# Altium schematic sheet SIM808+RF.SchDoc
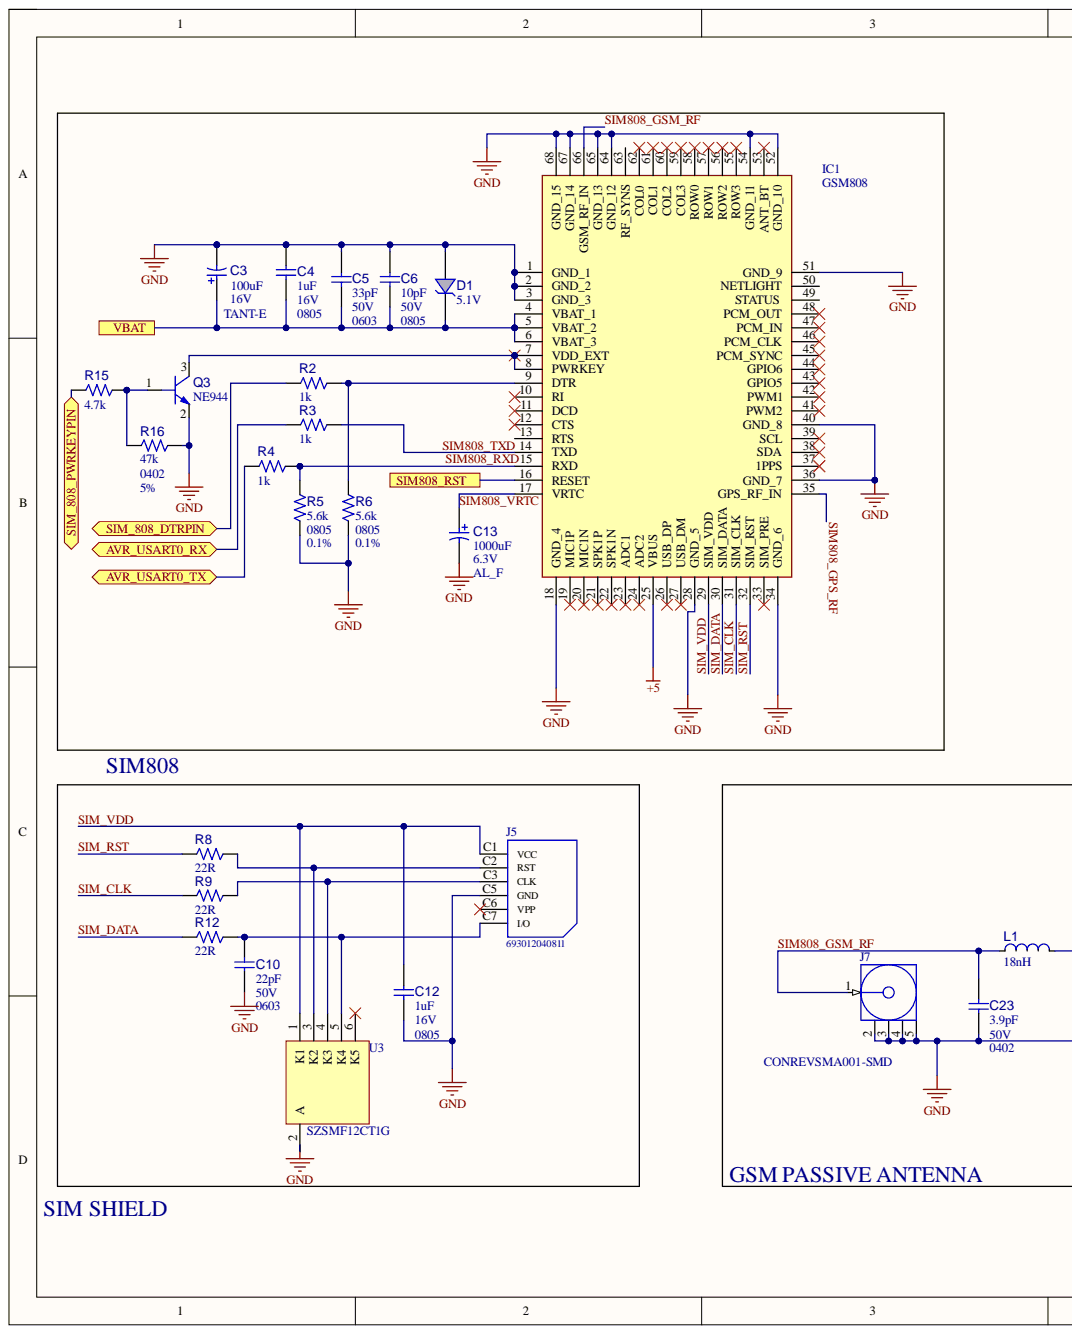
[diagram p0: SIM808+RF.SchDoc, left]
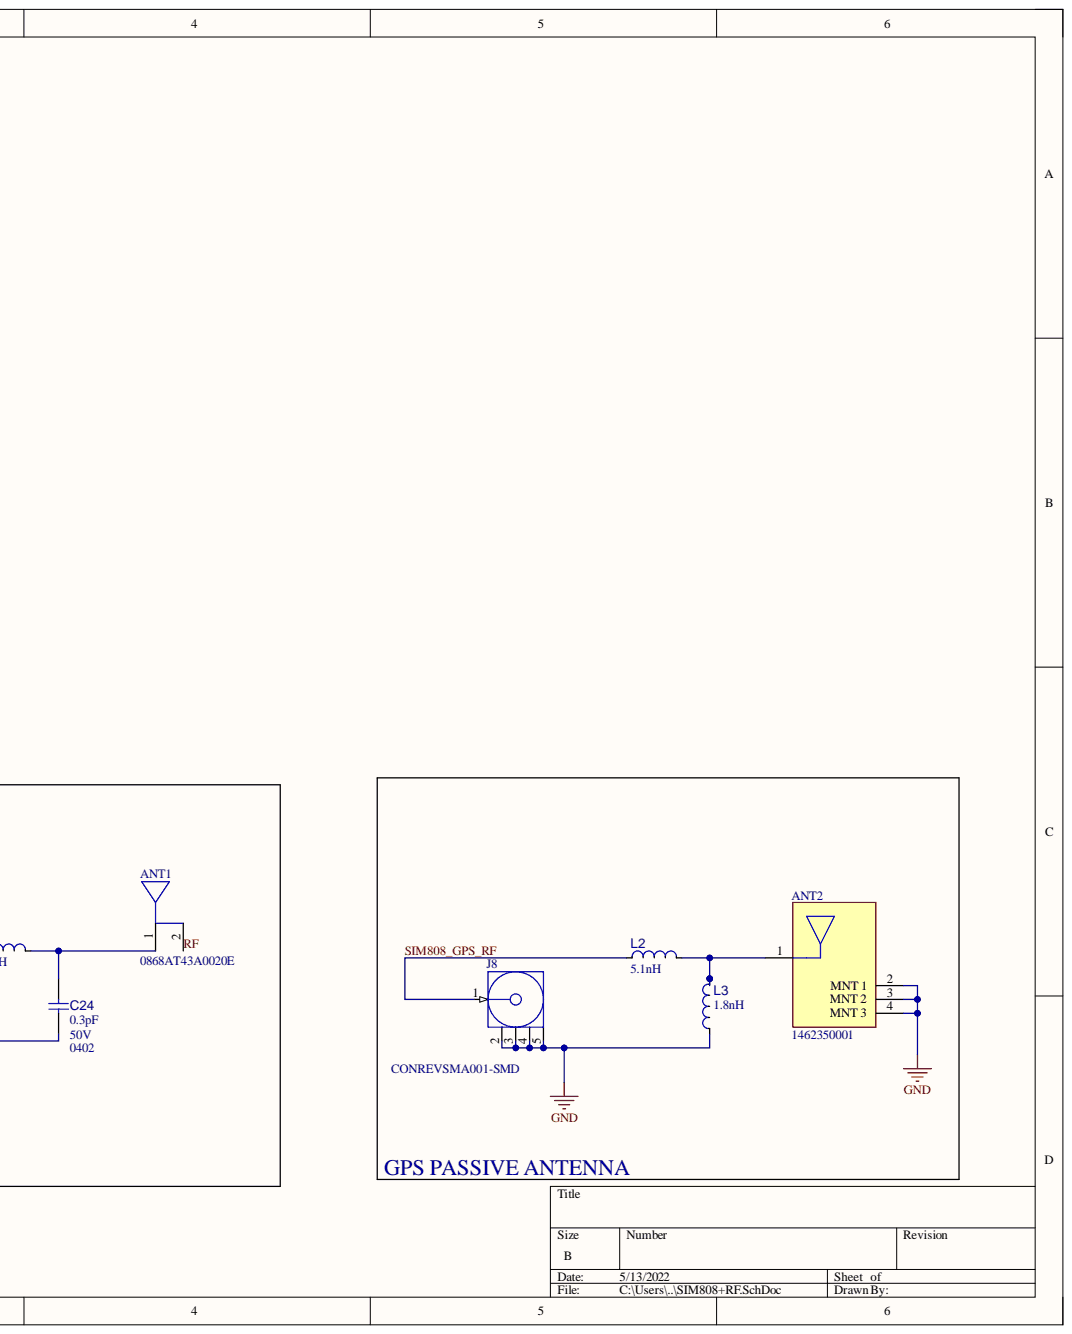
[diagram p1: SIM808+RF.SchDoc, right]

## Parts
ANT1  0868AT43A0020E  [FP-0900AT43A0070E-MFG]
ANT2  1462350001  [FP-1462350001-MFG]
C3  value 100uF  Package: TANT-E  Voltage: 16V  [CAP_TANT_E]  (hidden comment: Capacitor POL)
C4  value 1uF  Package: 0805  Voltage: 16V  [CAP_C_0805]  (hidden comment: Capacitor NP)
C5  value 33pF  Package: 0603  Voltage: 50V  [CAP_C_0603]  (hidden comment: Capacitor NP)
C6  value 10pF  Package: 0805  Voltage: 50V  [CAP_C_0805]  (hidden comment: Capacitor NP)
C10  value 22pF  Package: 0603  Voltage: 50V  [CAP_C_0603]  (hidden comment: Capacitor NP)
C12  value 1uF  Package: 0805  Voltage: 16V  [CAP_C_0805]  (hidden comment: Capacitor NP)
C13  value 1000uF  Package: AL_F  Voltage: 6.3V  [CAP_AL_F]  (hidden comment: Capacitor POL)
C23  value 3.9pF  Package: 0402  Voltage: 50V  [CAP_C_0402]  (hidden comment: Capacitor NP)
C24  value 0.3pF  Package: 0402  Voltage: 50V  [CAP_C_0402]  (hidden comment: Capacitor NP)
D1  value 5.1V  [SOD-123]  (hidden comment: Diode Zener)
IC1  GSM808  [GSM808]
J5  693012040811  [693012040811]
J7  CONREVSMA001-SMD  [CON-REVSMA-SMD]  (value not drawn)
J8  CONREVSMA001-SMD  [CON-REVSMA-SMD]  (value not drawn)
L1  value 18nH  [IND_C_0402]  (hidden comment: Inductor)
L2  value 5.1nH  [IND_C_0402]  (hidden comment: Inductor)
L3  value 1.8nH  [IND_C_0402]  (hidden comment: Inductor)
Q3  value NE944  [SOT-23-3]  (hidden comment: Transistor NPN BEC)
R2  value 1k  [RES_C_0603]  (hidden comment: Resistor)
R3  value 1k  [RES_C_0603]  (hidden comment: Resistor)
R4  value 1k  [RES_C_0603]  (hidden comment: Resistor)
R5  value 5.6k  Package: 0805  Tolerance: 0.1%  [RES_C_0805]  (hidden comment: Resistor)
R6  value 5.6k  Package: 0805  Tolerance: 0.1%  [RES_C_0805]  (hidden comment: Resistor)
R8  value 22R  [RES_C_8230]  (hidden comment: Resistor)
R9  value 22R  [RES_C_8230]  (hidden comment: Resistor)
R12  value 22R  [RES_C_8230]  (hidden comment: Resistor)
R15  value 4.7k  [RES_C_0603]  (hidden comment: Resistor)
R16  value 47k  Package: 0402  Tolerance: 5%  [RES_C_0402]  (hidden comment: Resistor)
U3  SZSMF12CT1G  [ONSC-SC70-6-419B-02_W_V]

## Ports
AVR_USART0_RX, AVR_USART0_TX, SIM808_RST, SIM_808_DTRPIN, SIM_808_PWRKEYPIN, VBAT

## Nets
+5: IC1.25(VBUS)
GND: ANT2.2(MNT_1) ANT2.3(MNT_2) ANT2.4(MNT_3) C3.2 C4.1 C5.1 C6.1 C10.1 C12.2 C13.2 C23.1 C24.1 D1.1 IC1.1(GND_1) IC1.2(GND_2) IC1.3(GND_3) IC1.18(GND_4) IC1.28(GND_5) IC1.34(GND_6) IC1.36(GND_7) IC1.40(GND_8) IC1.51(GND_9) IC1.52(GND_10) IC1.54(GND_11) IC1.64(GND_12) IC1.65(GND_13) IC1.67(GND_14) IC1.68(GND_15) J5.C5(GND) J7.2 J7.3 J7.4 J7.5 J8.2 J8.3 J8.4 J8.5 L3.1 Q3.2 R5.2 R6.2 R16.1 U3.2(A)
AVR_USART0_RX: R3.1 ; port AVR_USART0_RX
AVR_USART0_TX: R4.1 ; port AVR_USART0_TX
RF: ANT1.2
SIM808_GPS_RF: IC1.35(GPS_RF_IN) J8.1 L2.1
SIM808_GSM_RF: C23.2 IC1.66(GSM_RF_IN) J7.1 L1.1
SIM808_RST: IC1.16(RESET) ; port SIM808_RST
SIM808_RXD: IC1.15(RXD) R4.2 R5.1
SIM808_TXD: IC1.14(TXD) R3.2
SIM808_VRTC: C13.1 IC1.17(VRTC)
SIM_808_DTRPIN: R2.1 ; port SIM_808_DTRPIN
SIM_808_PWRKEYPIN: R15.1 ; port SIM_808_PWRKEYPIN
SIM_CLK: IC1.31(SIM_CLK) R9.1
SIM_DATA: IC1.30(SIM_DATA) R12.1
SIM_RST: IC1.32(SIM_RST) R8.1
SIM_VDD: C12.1 IC1.29(SIM_VDD) J5.C1(VCC) U3.1(K1)
VBAT: C3.1 C4.2 C5.2 C6.2 D1.2 IC1.4(VBAT_1) IC1.5(VBAT_2) IC1.6(VBAT_3) ; port VBAT
NetANT1_1: ANT1.1 C24.2 L1.2
NetANT2_1: ANT2.1 L2.2 L3.2
NetC10_2: C10.2 J5.C7(I/O) R12.2 U3.5(K4)
NetIC1_7: IC1.7(VDD_EXT) IC1.8(PWRKEY) Q3.3
NetIC1_9: IC1.9(DTR) R2.2 R6.1
NetJ5_C2: J5.C2(RST) R8.2 U3.3(K2)
NetJ5_C3: J5.C3(CLK) R9.2 U3.4(K3)
NetQ3_1: Q3.1 R15.2 R16.2

Not connected: IC1 10(RI) 11(DCD) 12(CTS) 13(RTS) 19(MIC1P) 20(MIC1N) 21(SPK1P) 22(SPK1N) 23(ADC1) 24(ADC2) 26(USB_DP) 27(USB_DM) 33(SIM_PRE) 37(1PPS) 38(SDA) 39(SCL) 41(PWM2) 42(PWM1) 43(GPIO5) 44(GPIO6) 45(PCM_SYNC) 46(PCM_CLK) 47(PCM_IN) 48(PCM_OUT) 49(STATUS) 50(NETLIGHT) 53(ANT_BT) 55(ROW3) 56(ROW2) 57(ROW1) 58(ROW0) 59 60 61 62(COL0) 63(RF_SYNS); J5 C6(VPP); U3 6(K5)

## Library data of the parts
ANT1 = CMP-56579-000002-1: RF ANT CHIP SOLDER SMD; Impedance: 50R; Max Frequency: 928MHz; Component Kind: Standard; Contact Plating: Tin; Library Name: Altium Content Vault; Mount: Surface Mount; Number of Pins: 2; RoHS Compliant: No
ANT2 = CMP-04721-000004-1: RF ANT 1.575GHZ MOLDED SLDR SMD; Manufacturer: Molex; Gain: 1.1dB; Impedance: 50Ω; Max Frequency: 1607MHz; Number Of Bands: 3; Power Rating: 2W; Return Loss: -8dB; Automotive: No; Category: Ant; Component Kind: Standard; ComponentLink2Description: Datasheet; ComponentLink2URL: https://www.molex.com/pdm_docs/sd/1462350001_sd.pdf; Device Class L1: Integrated Circuits (ICs); Device Class L2: RF Semiconductors and Devices; Device Class L3: RF Antennas; Digikey Description: RF ANT 1.575GHZ MOLDED SLDR SMD; Height: 4.1mm; Lead Free: Yes; Library Name: Altium Content Vault; Manufacturer Part Number: 1462350001; Octopart: https://octopart.com/146235-0001-molex-75198029; Package: 1462350001; RoHS: TRUE; Temperature: -40°C to +125°C; Temperature Range High: +125°C; Temperature Range Low: -40°C
C3 = CAP0030: Capacitor; Manufacturer 1: KEMET; Manufacturer Part Number 1: T491X107M016AT; Description_: CAP TANT 100uF 16V 20% 0.07Ohm SMD E; Dev Tools Status: Production; ESR: 70mOhm; Owner: Alexandru Chiser - M19201; Populated: YES; Tolerance: 20%; Type: Tantalum; Category: Capacitor; Component Kind: Standard; ComponentLink1Description: SVN Datasheet; ComponentLink1URL: https://chn-vm-apps.microchip.com/mchprepo/parts/Datasheets/KEM_TC101_STD.pdf; ComponentLink2Description: Local Datasheet; ComponentLink3Description: Open Symbol; ComponentLink4Description: Open Footprint; ComponentLink5Description: Open Footprint 2; ComponentLink6Description: Open Footprint 3; ComponentLink7Description: Open Footprint 4; Database Table Name: Capacitor; Library Name: MCHP-CDB.DbLib; Manufacturer 2: KEMET; Manufacturer 3: KEMET; Manufacturer Part Number 2: T491X107M016AG; Manufacturer Part Number 3: T491X107M016AS; MCHP-ID: CAP0030; Operating Temperature: -55C to +125C; Quantity Override: 1; Revision: 1; Status: MCL Design; Supplier 1: Digi-Key; Supplier 2: KEMET; Supplier 3: Digi-Key; Supplier Part Number 1: 399-3812-1-ND; Supplier Part Number 2: T491X107M016AG; Supplier Part Number 3: 399-1607-2-ND; Symbol: Capacitor POL; ComponentLink2URL: C:\ALTIUM_WORK\00001_MCHP-CDB\Datasheets\KEM_TC101_STD.pdf; ComponentLink3URL: C:\ALTIUM_WORK\00001_MCHP-CDB\Library\LibraryRefs\Capacitor POL.SchLib; ComponentLink4URL: C:\ALTIUM_WORK\00001_MCHP-CDB\Library\Footprints\CAP_TANT_E.PcbLib
C4 C12 = CAP0020: Manufacturer 1: AVX; Manufacturer Part Number 1: 0805YG105ZAT2A; Description_: CAP CER 1uF 16V 20% Y5V SMD 0805; Dev Tools Status: Production; Populated: Yes; Temperature Coefficient: Y5V; Tolerance: 20%; Type: Ceramic; Category: Capacitor; Component Kind: Standard; ComponentLink1Description: SVN Datasheet; ComponentLink1URL: https://chn-vm-apps.microchip.com/mchprepo/parts/Datasheets/smccp.pdf; ComponentLink2Description: Local Datasheet; ComponentLink3Description: Open Symbol; ComponentLink4Description: Open Footprint; ComponentLink5Description: Open Footprint 2; ComponentLink6Description: Open Footprint 3; ComponentLink7Description: Open Footprint 4; Database Table Name: Capacitor; Library Name: MCHP-CDB.DbLib; Manufacturer 2: Cal-Chip; Manufacturer 3: Panasonic; Manufacturer 4: NIC Components; Manufacturer 5: ROHM; Manufacturer Part Number 2: GMC21Y5V105Z16NTLF; Manufacturer Part Number 3: ECJ-2VF1C105Z; Manufacturer Part Number 4: NMC0805Y5V105Z16TRPLPF; Manufacturer Part Number 5: MCH213F105ZP; MCHP-ID: CAP0020; Operating Temperature: -30C to +85C; Quantity Override: 1; Revision: 1; Status: MCL Design; Supplier 1: AVX; Supplier 2: Cal-Chip; Supplier 3: Digi-Key; Supplier 4: Digi-Key; Supplier 5: NIC Components; Supplier 6: ROHM; Supplier Part Number 1: 0805YG105ZAT2A; Supplier Part Number 2: GMC21Y5V105Z16NTLF; Supplier Part Number 3: PCC1849TR-ND; Supplier Part Number 4: PCC1849CT-ND; Supplier Part Number 5: NMC0805Y5V105Z16TRPLPF; Supplier Part Number 6: MCH213F105ZP; Symbol: Capacitor NP; ComponentLink2URL: C:\ALTIUM_WORK\00001_MCHP-CDB\Datasheets\smccp.pdf; ComponentLink3URL: C:\ALTIUM_WORK\00001_MCHP-CDB\Library\LibraryRefs\Capacitor NP.SchLib; ComponentLink4URL: C:\ALTIUM_WORK\00001_MCHP-CDB\Library\Footprints\CAP_C_0805.PcbLib
C5 = CAP0088: Manufacturer 1: Cal-Chip; Manufacturer Part Number 1: GMC10CG330J50NTLF; Description_: CAP CER 33pF 50V 5% NP0 SMD 0603; Dev Tools Status: Production; Populated: Yes; Temperature Coefficient: NP0; Tolerance: 5%; Type: Ceramic; Category: Capacitor; Component Kind: Standard; ComponentLink1Description: SVN Datasheet; ComponentLink1URL: https://chn-vm-apps.microchip.com/mchprepo/parts/Datasheets/KEM_C1002_X7R_SMD.pdf; ComponentLink2Description: Local Datasheet; ComponentLink3Description: Open Symbol; ComponentLink4Description: Open Footprint; ComponentLink5Description: Open Footprint 2; ComponentLink6Description: Open Footprint 3; ComponentLink7Description: Open Footprint 4; Database Table Name: Capacitor; Library Name: MCHP-CDB.DbLib; Manufacturer 2: KEMET; Manufacturer 3: Panasonic; Manufacturer 4: TDK Corporation; Manufacturer 5: Yageo; Manufacturer 6: NIC Components; Manufacturer 7: Panasonic; Manufacturer 8: Samsung Electro-Mechanics; Manufacturer 9: Yageo; Manufacturer Part Number 2: C0603C330J5GACTU; Manufacturer Part Number 3: ECU-V1H330JCV; Manufacturer Part Number 4: C1608C0G1H330J; Manufacturer Part Number 5: CC0603JRNP09BN330; Manufacturer Part Number 6: NMC0603NPO330J50TRPF; Manufacturer Part Number 7: ECJ-1VC1H330J; Manufacturer Part Number 8: CL10C330JB8NNNC; Manufacturer Part Number 9: CC0603JRNPO9BN330; MCHP-ID: CAP0088; Operating Temperature: -55C to +125C; Quantity Override: 1; Revision: 4; Status: MCL Design; Supplier 1: Cal-Chip; Supplier 2: Digi-Key; Supplier 3: Digi-Key; Supplier 4: Digi-Key; Supplier 5: Digi-Key; Supplier 6: NIC Components; Supplier 7: Panasonic; Supplier 8: Samsung Electro-Mechanics; Supplier 9: Yageo; Supplier Part Number 1: GMC10CG330J50NTLF; Supplier Part Number 2: 399-1055-1-ND; Supplier Part Number 3: PCC330ACVTR-ND; Supplier Part Number 4: 445-1275-2-ND; Supplier Part Number 5: 311-1064-1-ND; Supplier Part Number 6: NMC0603NPO330J50TRPF; Supplier Part Number 7: ECJ-1VC1H330J; Supplier Part Number 8: CL10C330JB8NNNC; Supplier Part Number 9: CC0603JRNPO9BN330; Symbol: Capacitor NP; ComponentLink2URL: C:\ALTIUM_WORK\00001_MCHP-CDB\Datasheets\KEM_C1002_X7R_SMD.pdf; ComponentLink3URL: C:\ALTIUM_WORK\00001_MCHP-CDB\Library\LibraryRefs\Capacitor NP.SchLib; ComponentLink4URL: C:\ALTIUM_WORK\00001_MCHP-CDB\Library\Footprints\CAP_C_0603.PcbLib
C6 = CAP0044: Manufacturer 1: Cal-Chip; Manufacturer Part Number 1: GMC21NPO100J50NTLF; Description_: CAP CER 10pF 50V 5% NP0 SMD 0805; Dev Tools Status: Production; Populated: Yes; Temperature Coefficient: NP0; Tolerance: 5%; Type: Ceramic; Category: Capacitor; Component Kind: Standard; ComponentLink1Description: SVN Datasheet; ComponentLink1URL: https://chn-vm-apps.microchip.com/mchprepo/parts/Datasheets/ECJ__General_.pdf; ComponentLink2Description: Local Datasheet; ComponentLink3Description: Open Symbol; ComponentLink4Description: Open Footprint; ComponentLink5Description: Open Footprint 2; ComponentLink6Description: Open Footprint 3; ComponentLink7Description: Open Footprint 4; Database Table Name: Capacitor; Library Name: MCHP-CDB.DbLib; Manufacturer 2: Panasonic; Manufacturer 3: Panasonic; Manufacturer 4: Vishay; Manufacturer 5: Yageo; Manufacturer 6: NIC Components; Manufacturer Part Number 2: ECU-V1H100DCN; Manufacturer Part Number 3: ECJ-2VC1H100D; Manufacturer Part Number 4: VJ0805A100JXACW1BC; Manufacturer Part Number 5: 0805CG100J9B200; Manufacturer Part Number 6: NMC0805NP0100J50TRPF; MCHP-ID: CAP0044; Operating Temperature: -55C to +125C; Quantity Override: 1; Revision: 1; Status: MCL Design; Supplier 1: Cal-Chip; Supplier 2: Digi-Key; Supplier 3: Digi-Key; Supplier 4: Digi-Key; Supplier 5: NIC Components; Supplier Part Number 1: GMC21NPO100J50NTLF; Supplier Part Number 2: PCC100CNTR-ND; Supplier Part Number 3: BC1256TR-ND; Supplier Part Number 4: 311-1099-2-ND; Supplier Part Number 5: NMC0805NP0100J50TRPF; Symbol: Capacitor NP; ComponentLink2URL: C:\ALTIUM_WORK\00001_MCHP-CDB\Datasheets\ECJ__General_.pdf; ComponentLink3URL: C:\ALTIUM_WORK\00001_MCHP-CDB\Library\LibraryRefs\Capacitor NP.SchLib; ComponentLink4URL: C:\ALTIUM_WORK\00001_MCHP-CDB\Library\Footprints\CAP_C_0805.PcbLib
C10 = CAP0074: Manufacturer 1: Cal-Chip; Manufacturer Part Number 1: GMC10CG220J50NTLF; Description_: CAP CER 22pF 50V 5% NP0 SMD 0603; Dev Tools Status: Production; Populated: Yes; Temperature Coefficient: NP0; Tolerance: 5%; Type: Ceramic; Category: Capacitor; Component Kind: Standard; ComponentLink1Description: SVN Datasheet; ComponentLink1URL: https://chn-vm-apps.microchip.com/mchprepo/parts/Datasheets/ECJ__General_.pdf; ComponentLink2Description: Local Datasheet; ComponentLink3Description: Open Symbol; ComponentLink4Description: Open Footprint; ComponentLink5Description: Open Footprint 2; ComponentLink6Description: Open Footprint 3; ComponentLink7Description: Open Footprint 4; Database Table Name: Capacitor; Library Name: MCHP-CDB.DbLib; Manufacturer 2: Panasonic; Manufacturer 3: Panasonic; Manufacturer 4: Yageo; Manufacturer 5: Yageo; Manufacturer 6: NIC Components; Manufacturer 7: Samsung Electro-Mechanics; Manufacturer 8: Yageo; Manufacturer Part Number 2: ECJ-1VC1H220J; Manufacturer Part Number 3: ECU-V1H220JCV; Manufacturer Part Number 4: 0603CG220J9B200; Manufacturer Part Number 5: CC0603JRNP09BN220; Manufacturer Part Number 6: NMC0603NPO220J50TRPF; Manufacturer Part Number 7: CL10C220JB8NNNC; Manufacturer Part Number 8: CC0603JRNPO9BN220; MCHP-ID: CAP0074; Operating Temperature: -55C to +125C; Quantity Override: 1; Revision: 3; Status: MCL Design; Supplier 1: Cal-Chip; Supplier 2: Digi-Key; Supplier 3: Digi-Key; Supplier 4: NIC Components; Supplier 5: Samsung Electro-Mechanics; Supplier 6: Yageo; Supplier Part Number 1: GMC10CG220J50NTLF; Supplier Part Number 2: PCC220ACVTR-ND; Supplier Part Number 3: 311-1062-1-ND; Supplier Part Number 4: NMC0603NPO220J50TRPF; Supplier Part Number 5: CL10C220JB8NNNC; Supplier Part Number 6: CC0603JRNPO9BN220; Symbol: Capacitor NP; ComponentLink2URL: C:\ALTIUM_WORK\00001_MCHP-CDB\Datasheets\ECJ__General_.pdf; ComponentLink3URL: C:\ALTIUM_WORK\00001_MCHP-CDB\Library\LibraryRefs\Capacitor NP.SchLib; ComponentLink4URL: C:\ALTIUM_WORK\00001_MCHP-CDB\Library\Footprints\CAP_C_0603.PcbLib
C13 = CAP1172: Capacitor; Manufacturer 1: Panasonic Electronic Components; Manufacturer Part Number 1: EEE-0JA102UP; Description_: CAP ALU 1000uF 6.3V 20% SMD F; Dev Tools Status: Production; Owner: Bogdan P (M91398); Populated: YES; Tolerance: 20%; Type: Aluminum; Category: Capacitor; Component Kind: Standard; ComponentLink1Description: SVN Datasheet; ComponentLink1URL: https://chn-vm-apps.microchip.com/mchprepo/parts/Datasheets/S_Series_Type_V.pdf; ComponentLink2Description: Local Datasheet; ComponentLink3Description: Open Symbol; ComponentLink4Description: Open Footprint; ComponentLink5Description: Open Footprint 2; ComponentLink6Description: Open Footprint 3; ComponentLink7Description: Open Footprint 4; Database Table Name: Capacitor; Library Name: MCHP-CDB-Offline.DbLib; MCHP-ID: CAP1172; Operating Temperature: -40C to +85C; Quantity Override: 1; Revision: 1; Status: MCL Design; Supplier 1: Digi-Key; Supplier Part Number 1: PCE3855CT-ND; Symbol: Capacitor POL; ComponentLink2URL: C:\ALTIUM_WORK\00001_MCHP-CDB\Datasheets\S_Series_Type_V.pdf; ComponentLink3URL: C:\ALTIUM_WORK\00001_MCHP-CDB\Library\LibraryRefs\Capacitor POL.SchLib; ComponentLink4URL: C:\ALTIUM_WORK\00001_MCHP-CDB\Library\Footprints\CAP_AL_F.PcbLib
C23 = CAP1405: Manufacturer 1: Johanson Technology Inc; Manufacturer Part Number 1: 500R07S3R9BV4T; Description_: CAP HiQ 3.9pF 50V 0.1pF NP0 4.25GHz SMD 0402; Dev Tools Status: Design; ESR: 0.059R; Owner: Gabor Bihari; Populated: YES; Temperature Coefficient: NP0; Tolerance: 0.1pF; Type: HiQ; Category: Capacitor; Component Kind: Standard; ComponentLink1Description: SVN Datasheet; ComponentLink1URL: https://chn-vm-apps.microchip.com/mchprepo/parts/Datasheets/http://www.johansontechnology.com/downloads/JTI-CAT-MLCC-HighQ.pdf; ComponentLink2Description: Local Datasheet; ComponentLink3Description: Open Symbol; ComponentLink4Description: Open Footprint; ComponentLink5Description: Open Footprint 2; ComponentLink6Description: Open Footprint 3; ComponentLink7Description: Open Footprint 4; Database Table Name: Capacitor; Library Name: MCHP-CDB-Offline.DbLib; MCHP-ID: CAP1405; Operating Temperature: -55°C to 125°C; Quantity Override: 1; Revision: A; Status: MCL Design; Supplier 1: Digi-Key; Supplier Part Number 1: 712-1401-1-ND; Symbol: Capacitor NP; ComponentLink2URL: C:\ALTIUM_WORK\00001_MCHP-CDB\Datasheets\http://www.johansontechnology.com/downloads/JTI-CAT-MLCC-HighQ.pdf; ComponentLink3URL: C:\ALTIUM_WORK\00001_MCHP-CDB\Library\LibraryRefs\Capacitor NP.SchLib; ComponentLink4URL: C:\ALTIUM_WORK\00001_MCHP-CDB\Library\Footprints\CAP_C_0402.PcbLib
C24 = CAP1393: Manufacturer 1: Johanson Technology Inc; Manufacturer Part Number 1: 500R07S0R3AV4T; Description_: CAP HiQ 0.3pF 50V 0.05pF NP0 13.41GHz SMD 0402; Dev Tools Status: Design; ESR: 0.29R; Owner: Gabor Bihari; Populated: YES; Temperature Coefficient: NP0; Tolerance: 0.05pF; Type: HiQ; Category: Capacitor; Component Kind: Standard; ComponentLink1Description: SVN Datasheet; ComponentLink1URL: https://chn-vm-apps.microchip.com/mchprepo/parts/Datasheets/http://www.johansontechnology.com/downloads/JTI-CAT-MLCC-HighQ.pdf; ComponentLink2Description: Local Datasheet; ComponentLink3Description: Open Symbol; ComponentLink4Description: Open Footprint; ComponentLink5Description: Open Footprint 2; ComponentLink6Description: Open Footprint 3; ComponentLink7Description: Open Footprint 4; Database Table Name: Capacitor; Library Name: MCHP-CDB-Offline.DbLib; MCHP-ID: CAP1393; Operating Temperature: -55°C to 125°C; Quantity Override: 1; Revision: A; Status: MCL Design; Supplier 1: Digi-Key; Supplier Part Number 1: 712-1245-1-ND; Symbol: Capacitor NP; ComponentLink2URL: C:\ALTIUM_WORK\00001_MCHP-CDB\Datasheets\http://www.johansontechnology.com/downloads/JTI-CAT-MLCC-HighQ.pdf; ComponentLink3URL: C:\ALTIUM_WORK\00001_MCHP-CDB\Library\LibraryRefs\Capacitor NP.SchLib; ComponentLink4URL: C:\ALTIUM_WORK\00001_MCHP-CDB\Library\Footprints\CAP_C_0402.PcbLib
D1 = DIODE1230: Manufacturer 1: Fairchild Semiconductor; Manufacturer Part Number 1: MMSZ4689ET1G; Description_: DIO ZNR MMSZ4689ET1G 5.1V 500mW SMD SOD-123; Dev Tools Status: Production; Forward Current: 10mA; Owner: Cristian Papuc; Populated: YES; Power: 500mW; Reverse Current: 10µA; Reverse Voltage: 3V; Type: Zener Single; Category: Diode; Component Kind: Standard; ComponentLink1Description: SVN Datasheet; ComponentLink1URL: https://chn-vm-apps.microchip.com/mchprepo/parts/Datasheets/SZ,MMSZ4yyyET1G SeriesG_Series.pdf; ComponentLink2Description: Local Datasheet; ComponentLink3Description: Open Symbol; ComponentLink4Description: Open Footprint; ComponentLink5Description: Open Footprint 2; ComponentLink6Description: Open Footprint 3; ComponentLink7Description: Open Footprint 4; Database Table Name: Diode; Library Name: MCHP-CDB.DbLib; MCHP-ID: DIODE1230; Operating Temperature: -55C to +150C; Package: SOD-123; Quantity Override: 1; Revision: 1; Status: MCL Design; Supplier 1: Digi-Key; Supplier Part Number 1: MMSZ4689ET1GOSCT-ND; Symbol: Diode Zener; ComponentLink2URL: C:\ALTIUM_WORK\00001_MCHP-CDB\Datasheets\SZ,MMSZ4yyyET1G SeriesG_Series.pdf; ComponentLink3URL: C:\ALTIUM_WORK\00001_MCHP-CDB\Library\LibraryRefs\Diode Zener.SchLib; ComponentLink4URL: C:\ALTIUM_WORK\00001_MCHP-CDB\Library\Footprints\SOD-123.PcbLib
IC1 = GSM808: Integrated Circuit; Manufacturer_Name: SIMCOM; Component Kind: Standard; Datasheet Link: https://cdn-shop.adafruit.com/datasheets/SIM808_Hardware+Design_V1.00.pdf; Height: 2.8mm; Manufacturer_Part_Number: GSM808
J5 = CMP-1502-00168-1: WR-CRD Mini SIM Card Connector, Hinge Type; Manufacturer: Wurth Elektronik; Rated Current: 1A; Withstanding Voltage: 100VAC; Working Voltage: 250VAC; Component Kind: Standard; Datasheet URL: http://katalog.we-online.de/em/datasheet/693012040811.pdf; Library Name: Altium Content Vault; Manufacturer URL: http://www.we-online.de; Mounting Technology: SMT; Operating Temperature: -25to85degC; Package Reference: 693012040811; Packaging: TapeandReel; Part Number: 693012040811; Publisher: WurthElektronik
J7 J8 = CMP-0168-00009-1: RP-SMA Female Surface Mount Connector; Component Kind: Standard; DatasheetVersion: rev1, May-2006; Library Name: Altium Content Vault; PackageDescription: RP-SMA Female PCB Mount, Surface Mount Connector, 7 x 7 mm Body; PackageReference: CON-REVSMA-SMD; PackageVersion: rev1, May-2006
L1 = IND1183: Manufacturer 1: Johanson Technology Inc; Manufacturer Part Number 1: L-07C18NJV6T; DCR: 0.65R; Description_: INDUCTOR HiQ 18nH 200mA 5% 2.378GHz SMD 0402; Dev Tools Status: Production; Owner: Gabor Bihari; Populated: YES; RMS Current: 200mA; Test Frequency: 100MHz; Type: HiQ; Category: Inductor; Component Kind: Standard; ComponentLink1Description: SVN Datasheet; ComponentLink1URL: https://chn-vm-apps.microchip.com/mchprepo/parts/Datasheets/jti-cat-rf-ind.pdf; ComponentLink2Description: Local Datasheet; ComponentLink3Description: Open Symbol; ComponentLink4Description: Open Footprint; ComponentLink5Description: Open Footprint 2; ComponentLink6Description: Open Footprint 3; ComponentLink7Description: Open Footprint 4; Database Table Name: Inductor; Description: Inductor; Library Name: MCHP-CDB-Offline.DbLib; MCHP-ID: IND1183; Operating Temperature: -40°C to 100°C; Package: 0402; Quantity Override: 1; Revision: 1; Status: MCL Design; Supplier 1: Digikey; Supplier Part Number 1: 712-1409-1-ND; Symbol: Inductor; ComponentLink2URL: C:\ALTIUM_WORK\00001_MCHP-CDB\Datasheets\jti-cat-rf-ind.pdf; ComponentLink3URL: C:\ALTIUM_WORK\00001_MCHP-CDB\Library\LibraryRefs\Inductor.SchLib; ComponentLink4URL: C:\ALTIUM_WORK\00001_MCHP-CDB\Library\Footprints\IND_C_0402.PcbLib
L2 = IND1197: Manufacturer 1: Johanson Technology Inc; Manufacturer Part Number 1: L-07C5N1SV6T; DCR: 0.26R; Description_: INDUCTOR HiQ 5.1nH 300mA 0.3nH SRF=5.5GHz Q=8 SMD 0402; Dev Tools Status: Design; Owner: Gabor Bihari; Populated: YES; RMS Current: 300mA; Test Frequency: 100MHz; Type: HiQ; Category: Inductor; Component Kind: Standard; ComponentLink1Description: SVN Datasheet; ComponentLink1URL: https://chn-vm-apps.microchip.com/mchprepo/parts/Datasheets/jti-cat-rf-ind.pdf; ComponentLink2Description: Local Datasheet; ComponentLink3Description: Open Symbol; ComponentLink4Description: Open Footprint; ComponentLink5Description: Open Footprint 2; ComponentLink6Description: Open Footprint 3; ComponentLink7Description: Open Footprint 4; Database Table Name: Inductor; Description: Inductor; Library Name: MCHP-CDB-Offline.DbLib; MCHP-ID: IND1197; Operating Temperature: -40°C to 100°C; Package: 0402; Quantity Override: 1; Revision: C; Status: MCL Design; Supplier 1: Digi-Key; Supplier Part Number 1: 712-1461-1-ND; Symbol: Inductor; ComponentLink2URL: C:\ALTIUM_WORK\00001_MCHP-CDB\Datasheets\jti-cat-rf-ind.pdf; ComponentLink3URL: C:\ALTIUM_WORK\00001_MCHP-CDB\Library\LibraryRefs\Inductor.SchLib; ComponentLink4URL: C:\ALTIUM_WORK\00001_MCHP-CDB\Library\Footprints\IND_C_0402.PcbLib
L3 = IND1194: Manufacturer 1: Johanson Technology Inc; Manufacturer Part Number 1: L-07C1N8SV6T; DCR: 0.14R; Description_: INDUCTOR HiQ 1.8nH 300mA 0.3nH 10.505GHz SMD 0402; Dev Tools Status: Design; Owner: Gabor Bihari; Populated: YES; RMS Current: 300mA; Test Frequency: 100MHz; Type: HiQ; Category: Inductor; Component Kind: Standard; ComponentLink1Description: SVN Datasheet; ComponentLink1URL: https://chn-vm-apps.microchip.com/mchprepo/parts/Datasheets/jti-cat-rf-ind.pdf; ComponentLink2Description: Local Datasheet; ComponentLink3Description: Open Symbol; ComponentLink4Description: Open Footprint; ComponentLink5Description: Open Footprint 2; ComponentLink6Description: Open Footprint 3; ComponentLink7Description: Open Footprint 4; Database Table Name: Inductor; Description: Inductor; Library Name: MCHP-CDB-Offline.DbLib; MCHP-ID: IND1194; Operating Temperature: -40°C to 100°C; Package: 0402; Quantity Override: 1; Revision: D; Status: MCL Design; Supplier 1: Digi-Key; Supplier Part Number 1: 712-1412-1-ND; Symbol: Inductor; ComponentLink2URL: C:\ALTIUM_WORK\00001_MCHP-CDB\Datasheets\jti-cat-rf-ind.pdf; ComponentLink3URL: C:\ALTIUM_WORK\00001_MCHP-CDB\Library\LibraryRefs\Inductor.SchLib; ComponentLink4URL: C:\ALTIUM_WORK\00001_MCHP-CDB\Library\Footprints\IND_C_0402.PcbLib
Q3 = TRA0090: Transistor Bipolar; Manufacturer 1: NEC; Manufacturer Part Number 1: NE94433-T1B-A; Current: 50mA; Description_: TRANS BJT NPN NE944 15V 50mA 150mW SOT-23-3; Dev Tools Status: Production; Populated: YES; Power: 150mW; Type: BJT; Voltage: 15V; Category: Transistor; Component Kind: Standard; ComponentLink1Description: SVN Datasheet; ComponentLink1URL: https://chn-vm-apps.microchip.com/mchprepo/parts/Datasheets/ne944.pdf; ComponentLink2Description: Local Datasheet; ComponentLink3Description: Open Symbol; ComponentLink4Description: Open Footprint; ComponentLink5Description: Open Footprint 2; ComponentLink6Description: Open Footprint 3; ComponentLink7Description: Open Footprint 4; Database Table Name: Transistor; Library Name: MCHP-CDB.DbLib; MCHP-ID: TRA0090; Operating Temperature: -55C to +125C; Package: SOT-23-3; Quantity Override: 1; Revision: 2; Status: MCL Design; Supplier 1: Digi-Key; Supplier Part Number 1: NE94433B-ACT-ND; Symbol: Transistor NPN BEC; ComponentLink2URL: C:\ALTIUM_WORK\00001_MCHP-CDB\Datasheets\ne944.pdf; ComponentLink3URL: C:\ALTIUM_WORK\00001_MCHP-CDB\Library\LibraryRefs\Transistor NPN BEC.SchLib; ComponentLink4URL: C:\ALTIUM_WORK\00001_MCHP-CDB\Library\Footprints\SOT-23-3.PcbLib
R2-R4 = RES1015: Manufacturer 1: Panasonic - ECG; Manufacturer Part Number 1: ERA-3AEB102V; Description_: RES TF 1k 0.1% 1/10W SMD 0603; Dev Tools Status: Production; Owner: Tad Ahlvers; Populated: YES; Power: 1/10W; Temperature Coefficient: 25ppm/C; Voltage: 75V; Category: Resistor; Component Kind: Standard; ComponentLink1Description: SVN Datasheet; ComponentLink1URL: https://chn-vm-apps.microchip.com/mchprepo/parts/Datasheets/AOA0000CE26.pdf; ComponentLink2Description: Local Datasheet; ComponentLink3Description: Open Symbol; ComponentLink4Description: Open Footprint; ComponentLink5Description: Open Footprint 2; ComponentLink6Description: Open Footprint 3; ComponentLink7Description: Open Footprint 4; Composition: TF; Database Table Name: Resistor; Description: Resistor; Library Name: MCHP-CDB.DbLib; Manufacturer 2: TE Connectivity; Manufacturer Part Number 2: 1614882-3; MCHP-ID: RES1015; Operating Temperature: -55C to +155C; Quantity Override: 1; Revision: 1; Status: MCL Design; Supplier 1: Digi-Key; Supplier 2: Digi-Key; Supplier Part Number 1: P1.0KDBTR-ND; Supplier Part Number 2: A102332TR-ND; Symbol: Resistor; Type: RES SMD; ComponentLink2URL: C:\ALTIUM_WORK\00001_MCHP-CDB\Datasheets\AOA0000CE26.pdf; ComponentLink3URL: C:\ALTIUM_WORK\00001_MCHP-CDB\Library\LibraryRefs\Resistor.SchLib; ComponentLink4URL: C:\ALTIUM_WORK\00001_MCHP-CDB\Library\Footprints\RES_C_0603.PcbLib
R5 R6 = RES1373: Manufacturer 1: Panasonic Electronic Components; Manufacturer Part Number 1: ERA-6AEB562V; Circuit Type: Isolated; Description_: RES TF 5.6k 0.1% 1/8W SMD 0805; Dev Tools Status: Production; Owner: Jairuz Agang-ang; Populated: YES; Power: 1/8W; Temperature Coefficient: 25ppm/C; Voltage: 100V; Category: Resistor; Component Kind: Standard; ComponentLink1Description: SVN Datasheet; ComponentLink1URL: https://chn-vm-apps.microchip.com/mchprepo/parts/Datasheets/AOA0000CE26.pdf; ComponentLink2Description: Local Datasheet; ComponentLink3Description: Open Symbol; ComponentLink4Description: Open Footprint; ComponentLink5Description: Open Footprint 2; ComponentLink6Description: Open Footprint 3; ComponentLink7Description: Open Footprint 4; Composition: TF; Database Table Name: Resistor; Description: Resistor; Library Name: MCHP-CDB.DbLib; MCHP-ID: RES1373; Operating Temperature: –55C to +155C; Quantity Override: 1; Revision: 0; Status: MCL Design; Supplier 1: Digi-Key; Supplier Part Number 1: P5.6KDACT-ND; Symbol: Resistor; Type: RES SMD; ComponentLink2URL: C:\ALTIUM_WORK\00001_MCHP-CDB\Datasheets\AOA0000CE26.pdf; ComponentLink3URL: C:\ALTIUM_WORK\00001_MCHP-CDB\Library\LibraryRefs\Resistor.SchLib; ComponentLink4URL: C:\ALTIUM_WORK\00001_MCHP-CDB\Library\Footprints\RES_C_0805.PcbLib
R8 R9 R12 = RES1017: Manufacturer 1: Riedon; Manufacturer Part Number 1: S5-22RF1; Description_: RES WW 22R 1% 4W SMD 8230 J-Lead; Dev Tools Status: Production; Owner: Mike Ragsdale; Populated: YES; Power: 4W; Temperature Coefficient: 20ppm/C; Voltage: 500V; Category: Resistor; Component Kind: Standard; ComponentLink1Description: SVN Datasheet; ComponentLink1URL: https://chn-vm-apps.microchip.com/mchprepo/parts/Datasheets/SSL.pdf; ComponentLink2Description: Local Datasheet; ComponentLink3Description: Open Symbol; ComponentLink4Description: Open Footprint; ComponentLink5Description: Open Footprint 2; ComponentLink6Description: Open Footprint 3; ComponentLink7Description: Open Footprint 4; Database Table Name: Resistor; Description: Resistor; Library Name: MCHP-CDB.DbLib; MCHP-ID: RES1017; Operating Temperature: -55C to +275C; Quantity Override: 1; Revision: 1; Status: MCL Design; Supplier 1: Digi-Key; Supplier Part Number 1: 696-1261-1-ND; Symbol: Resistor; Type: RES SMD; ComponentLink2URL: C:\ALTIUM_WORK\00001_MCHP-CDB\Datasheets\SSL.pdf; ComponentLink3URL: C:\ALTIUM_WORK\00001_MCHP-CDB\Library\LibraryRefs\Resistor.SchLib; ComponentLink4URL: C:\ALTIUM_WORK\00001_MCHP-CDB\Library\Footprints\RES_C_8230.PcbLib
R15 = RES1178: Manufacturer 1: Panasonic; Manufacturer Part Number 1: ERJ-3GEYJ472V; Description_: RES TKF 4.7k 5% 1/10W SMD 0603; Dev Tools Status: Production; Owner: Bogdan P (M91398); Populated: Yes; Power: 1/10W; Temperature Coefficient: 200ppm/C; Voltage: 75V; Category: Resistor; Component Kind: Standard; ComponentLink1Description: SVN Datasheet; ComponentLink1URL: https://chn-vm-apps.microchip.com/mchprepo/parts/Datasheets/AOA0000CE1.pdf; ComponentLink2Description: Local Datasheet; ComponentLink3Description: Open Symbol; ComponentLink4Description: Open Footprint; ComponentLink5Description: Open Footprint 2; ComponentLink6Description: Open Footprint 3; ComponentLink7Description: Open Footprint 4; Composition: TKF; Database Table Name: Resistor; Description: Resistor; Library Name: MCHP-CDB-Offline.DbLib; MCHP-ID: RES1178; Operating Temperature: -55C to +155C; Quantity Override: 1; Revision: 0; Status: MCL Design; Supplier 1: Digi-Key; Supplier Part Number 1: P4.7KGCT-ND; Symbol: Resistor; Type: RES SMD; ComponentLink2URL: C:\ALTIUM_WORK\00001_MCHP-CDB\Datasheets\AOA0000CE1.pdf; ComponentLink3URL: C:\ALTIUM_WORK\00001_MCHP-CDB\Library\LibraryRefs\Resistor.SchLib; ComponentLink4URL: C:\ALTIUM_WORK\00001_MCHP-CDB\Library\Footprints\RES_C_0603.PcbLib
R16 = RES1038: Manufacturer 1: Panasonic; Manufacturer Part Number 1: ERJ-2GEJ473X; Description_: RES TKF 47k 5% 1/10W SMD 0402; Dev Tools Status: Production; Owner: Tad Ahlvers; Populated: YES; Power: 1/10W; Temperature Coefficient: 200ppm/C; Voltage: 50V; Category: Resistor; Component Kind: Standard; ComponentLink1Description: SVN Datasheet; ComponentLink1URL: https://chn-vm-apps.microchip.com/mchprepo/parts/Datasheets/AOA0000CE1.pdf; ComponentLink2Description: Local Datasheet; ComponentLink3Description: Open Symbol; ComponentLink4Description: Open Footprint; ComponentLink5Description: Open Footprint 2; ComponentLink6Description: Open Footprint 3; ComponentLink7Description: Open Footprint 4; Composition: TKF; Database Table Name: Resistor; Description: Resistor; Library Name: MCHP-CDB-Offline.DbLib; MCHP-ID: RES1038; Operating Temperature: -55C to +155C; Quantity Override: 1; Revision: 1; Status: MCL Design; Supplier 1: Digi-Key; Supplier Part Number 1: P47KJTR-ND; Symbol: Resistor; Type: RES SMD; ComponentLink2URL: C:\ALTIUM_WORK\00001_MCHP-CDB\Datasheets\AOA0000CE1.pdf; ComponentLink3URL: C:\ALTIUM_WORK\00001_MCHP-CDB\Library\LibraryRefs\Resistor.SchLib; ComponentLink4URL: C:\ALTIUM_WORK\00001_MCHP-CDB\Library\Footprints\RES_C_0402.PcbLib
U3 = CMP-1061-00160-1: 5-Line Transient Voltage Suppressor Array, 6-Pin SC70, Pb-Free, Tape and Reel; Manufacturer: On Semiconductor; Direction: Unidirectional; IR Max (uA): 0.1; PPK Max (W): 100; Component Kind: Standard; ComponentLink1Description: Manufacturer URL; ComponentLink1URL: http://www.onsemi.com/; ComponentLink2Description: Datasheet; ComponentLink2URL: http://www.onsemi.com/pub_link/Collateral/SMF05C-D.PDF; ComponentLink3Description: Package Specification; ComponentLink3URL: http://www.onsemi.com/pub_link/Collateral/SMF05C-D.PDF; DatasheetVersion: Rev. 4; Library Name: Altium Content Vault; Mounting Technology: Surface Mount; PackageDescription: 6-Pin Small Outline Transistor, Body 2 x 1.25 mm, Pitch 0.65 mm; PackageReference: SC70-6-419B-02_W; PackageVersion: Rev. W; Packing: Tape and Reel; PartNumber: SZSMF12CT1G; RoHS: Pb-Free
